# Altium schematic sheet uC.SchDoc(Designator)
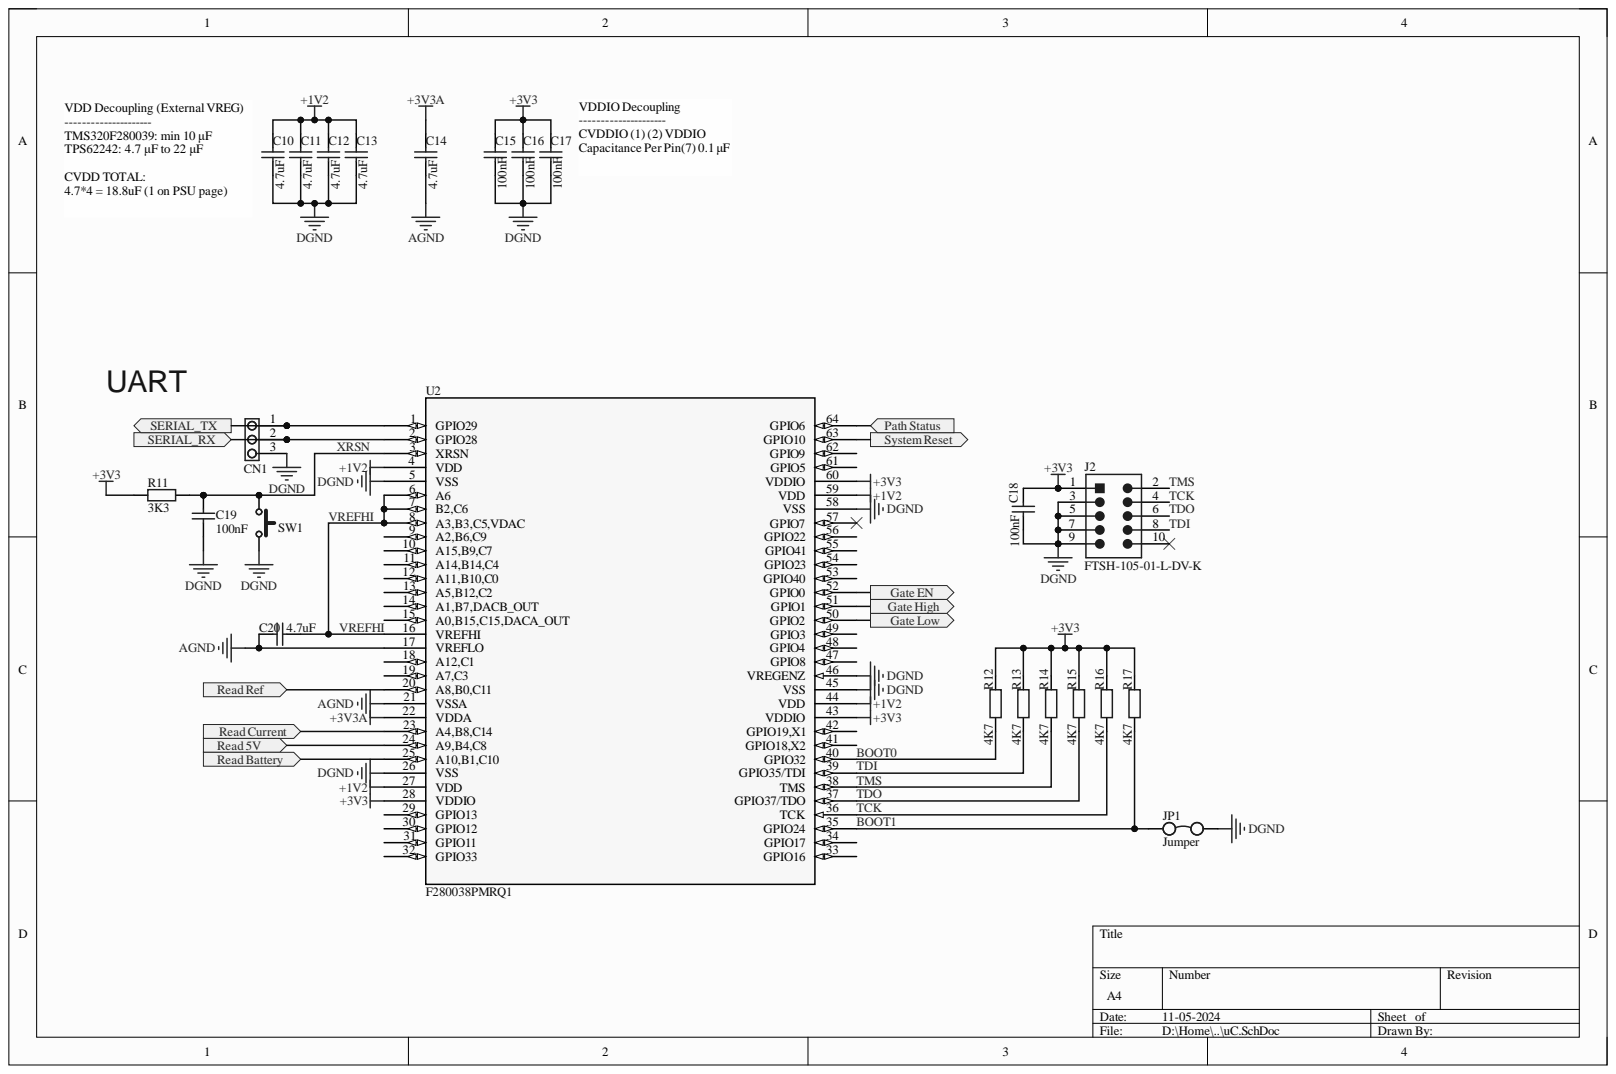
[diagram p0: uC.SchDoc]

## Parts
C10  4.7uF  [CAP0603]
C11  4.7uF  [CAP0603]
C12  4.7uF  [CAP0603]
C13  4.7uF  [CAP0603]
C14  4.7uF  [CAP0603]
C15  100nF  [CAP0603]
C16  100nF  [CAP0603]
C17  100nF  [CAP0603]
C18  100nF  [CAP0603]
C19  100nF  [CAP0603]
C20  4.7uF  [CAP0603]
CN1  Pins 1x3  [PIN-1X3]  (value not drawn)
J2  FTSH-105-01-L-DV-K  [CON-2x5-1.27]
JP1  Jumper  [PIN-1X2]
R11  3K3  [RES0603]
R12  4K7  [RES0603]
R13  4K7  [RES0603]
R14  4K7  [RES0603]
R15  4K7  [RES0603]
R16  4K7  [RES0603]
R17  4K7  [RES0603]
SW1  PTS526 Button  [PTS526 SMG15J SMTR2 LFS]  (value not drawn)
U2  F280038PMRQ1  [LQFP64]

## Ports
Gate EN, Gate High, Gate Low, Path Status, Read 5V, Read Battery, Read Current, Read Ref, SERIAL_RX, SERIAL_TX, System Reset

## Nets
+1V2: C10.2 C11.2 C12.2 C13.2 U2.4(VDD) U2.27(VDD) U2.44(VDD) U2.59(VDD)
+3V3: C15.2 C16.2 C17.2 C18.2 J2.1 R11.2 R12.2 R13.2 R14.2 R15.2 R16.2 R17.2 U2.28(VDDIO) U2.43(VDDIO) U2.60(VDDIO)
+3V3A: C14.2 U2.22(VDDA)
AGND: C14.1 C20.1 U2.17(VREFLO) U2.21(VSSA)
DGND: C10.1 C11.1 C12.1 C13.1 C15.1 C16.1 C17.1 C18.1 C19.2 CN1.3 J2.3 J2.5 J2.7 J2.9 JP1.2 SW1.1 U2.5(VSS) U2.26(VSS) U2.45(VSS) U2.46(VREGENZ) U2.58(VSS)
BOOT0: R12.1 U2.40(GPIO32)
BOOT1: JP1.1 R17.1 U2.35(GPIO24)
Gate EN: U2.52(GPIO0) ; port Gate EN
Gate High: U2.51(GPIO1) ; port Gate High
Gate Low: U2.50(GPIO2) ; port Gate Low
Path Status: U2.64(GPIO6) ; port Path Status
Read 5V: U2.24(A9,B4,C8) ; port Read 5V
Read Battery: U2.25(A10,B1,C10) ; port Read Battery
Read Current: U2.23(A4,B8,C14) ; port Read Current
Read Ref: U2.20(A8,B0,C11) ; port Read Ref
SERIAL_RX: CN1.2 U2.2(GPIO28) ; port SERIAL_RX
SERIAL_TX: CN1.1 U2.1(GPIO29) ; port SERIAL_TX
System Reset: U2.63(GPIO10) ; port System Reset
TCK: J2.4 R16.1 U2.36(TCK)
TDI: J2.8 R13.1 U2.39(GPIO35/TDI)
TDO: J2.6 R15.1 U2.37(GPIO37/TDO)
TMS: J2.2 R14.1 U2.38(TMS)
VREFHI: C20.2 U2.6(A6) U2.7(B2,C6) U2.8(A3,B3,C5,VDAC) U2.16(VREFHI)
XRSN: C19.1 R11.1 SW1.2 U2.3(XRSN)
NetJ2_10: J2.10
NetU2_9: U2.9(A2,B6,C9)
NetU2_10: U2.10(A15,B9,C7)
NetU2_11: U2.11(A14,B14,C4)
NetU2_12: U2.12(A11,B10,C0)
NetU2_13: U2.13(A5,B12,C2)
NetU2_14: U2.14(A1,B7,DACB_OUT)
NetU2_15: U2.15(A0,B15,C15,DACA_OUT)
NetU2_18: U2.18(A12,C1)
NetU2_19: U2.19(A7,C3)
NetU2_29: U2.29(GPIO13)
NetU2_30: U2.30(GPIO12)
NetU2_31: U2.31(GPIO11)
NetU2_32: U2.32(GPIO33)
NetU2_33: U2.33(GPIO16)
NetU2_34: U2.34(GPIO17)
NetU2_41: U2.41(GPIO18,X2)
NetU2_42: U2.42(GPIO19,X1)
NetU2_47: U2.47(GPIO8)
NetU2_48: U2.48(GPIO4)
NetU2_49: U2.49(GPIO3)
NetU2_53: U2.53(GPIO40)
NetU2_54: U2.54(GPIO23)
NetU2_55: U2.55(GPIO41)
NetU2_56: U2.56(GPIO22)
NetU2_57: U2.57(GPIO7)
NetU2_61: U2.61(GPIO5)
NetU2_62: U2.62(GPIO9)

## Library data of the parts
C10-C14 C20 = 4.7uF: Capacitor; Component Kind: Standard; Value: 4.7uF; Library Name: UPS_Schlib.SchLib
C15-C19 = 100nF: Capacitor; Component Kind: Standard; Value: 100nF; Library Name: UPS_Schlib.SchLib
CN1 = Pins 1x3: 1x3 2.54mm; Part Class: Connector; CompType: M50-3530342; Order Code: 855-M50-3530342; Component Kind: Standard; Distributor: Mouser; Package: SMD 1.27mm; Library Name: UPS_Schlib.SchLib
J2 = Connector_10Pin: Smt Micro Header; Manufacturer: Samtec; Current capacity: 3.4A; Gender: Male; Max Voltage: 0V; Order Code: 200-FTSH10501LDVK; Safety Related: No; Component Kind: Standard; CompType: FTSH-105-01-L-DV-K; Connector Type: Header; Distributor: Mouser; Max Operating Temperature: 125°C; Min Operating Temperature: -55°C; Mounting Technology: SMD; Package: SMD; Pins: 10; RoHS Compliant: Yes; Library Name: UPS_Schlib.SchLib
JP1 = Jumper: 2 pin jumper connection; Component Kind: Standard; Library Name: UPS_Schlib.SchLib
R11 = 3K3: Fixed Resistor, Metal Glaze/thick Film, 0.1W, 3300ohm, 75V, 1% +/-Tol, 150ppm/Cel, Surface Mount, 0603; Manufacturer: Yageo; Manufacturer Part Number: RC0603FR-073K3P; Additional Feature: LASER TRIMMABLE; Rated Temperature: 70°C; Temperature Coefficient: 150ppm/°C; Case/Package: 0603; Category: Chip SMD Resistors; Component Kind: Standard; ComponentLink1Description: https://datasheet.ciiva.com:443/pdfs/VipMasterIC/IC/YAGO/YAGO-S-A0006248068/YAGO-S-A0006248068-1.pdf?src-supplier=IHS+Markit; ComponentLink1URL: https://datasheet.ciiva.com:443/pdfs/VipMasterIC/IC/YAGO/YAGO-S-A0006248068/YAGO-S-A0006248068-1.pdf?src-supplier=IHS+Markit; ComponentLink2Description: http://www.yageo.com.tw/exep/pages/download/literatures/PYu-R_INT-thick_7.pdf?src-supplier=Digikey; ComponentLink2URL: http://www.yageo.com.tw/exep/pages/download/literatures/PYu-R_INT-thick_7.pdf?src-supplier=Digikey; ComponentLink3Description: http://www.yageo.com/NewPortal/yageodocoutput?fileName=/pdf/R-Chip/PYu-RC_51_RoHS_P_0.pdf&src-supplier=Digikey; ComponentLink3URL: http://www.yageo.com/NewPortal/yageodocoutput?fileName=/pdf/R-Chip/PYu-RC_51_RoHS_P_0.pdf&src-supplier=Digikey; JESD-609 Code: e3; Max Operating Temperature: 155°C; Min Operating Temperature: -55°C; Mounting Technology: Surface Mount; Package Shape: Rectangular; Packaging: Tape and Reel; Pins: 2; Pricing: 1=0.1, 10=0.017, 50=0.017, 100=0.01, 1000=0.008, 10000=0.004 (USD); Reach SVHC Compliant: Yes; Resistor Type: FIXED RESISTOR; RoHS: Yes; RoHS Compliant: Yes; Stock: 14148; Supplier Part Number: 603-RC0603FR-073K3P; Technology: METAL GLAZE/THICK FILM; Terminal Finish: Nickel; Termination: Wraparound; Value: 3K3; Working Voltage: 75V; Library Name: UPS_Schlib.SchLib
R12-R17 = 4K7: Resistor; Component Kind: Standard; Value: 4K7; Library Name: UPS_Schlib.SchLib
SW1 = PTS526 Button: PTS526 SMG15J SMTR2 LFS; Part Class: Other; CompType: DTSM 32N/SMD; Note: DIPTRONICS; Component Kind: Standard; Distributor: RET; Order Code: 52-01-71; Library Name: UPS_Schlib.SchLib
U2 = F280038CPMRQ1: Automotive C2000™ 32-bit MCU 120-MHz 384-KB flash, FPU, TMU with CLA, AES and CAN-FD; Mfr_Name: Texas Instruments; CompType: TMS320F280038-Q1; Component Kind: Standard; Distributor: TI; Manufacturer_Part_Number: F280038PMRQ1; RefDes: RefDes; Type: DEV; Copyright: Copyright (C) 2023 Ultra Librarian. All rights reserved.; Library Name: UPS_Schlib.SchLib
